# Altium schematic sheet ExternalI2C.SchDoc(ExternalI2C)
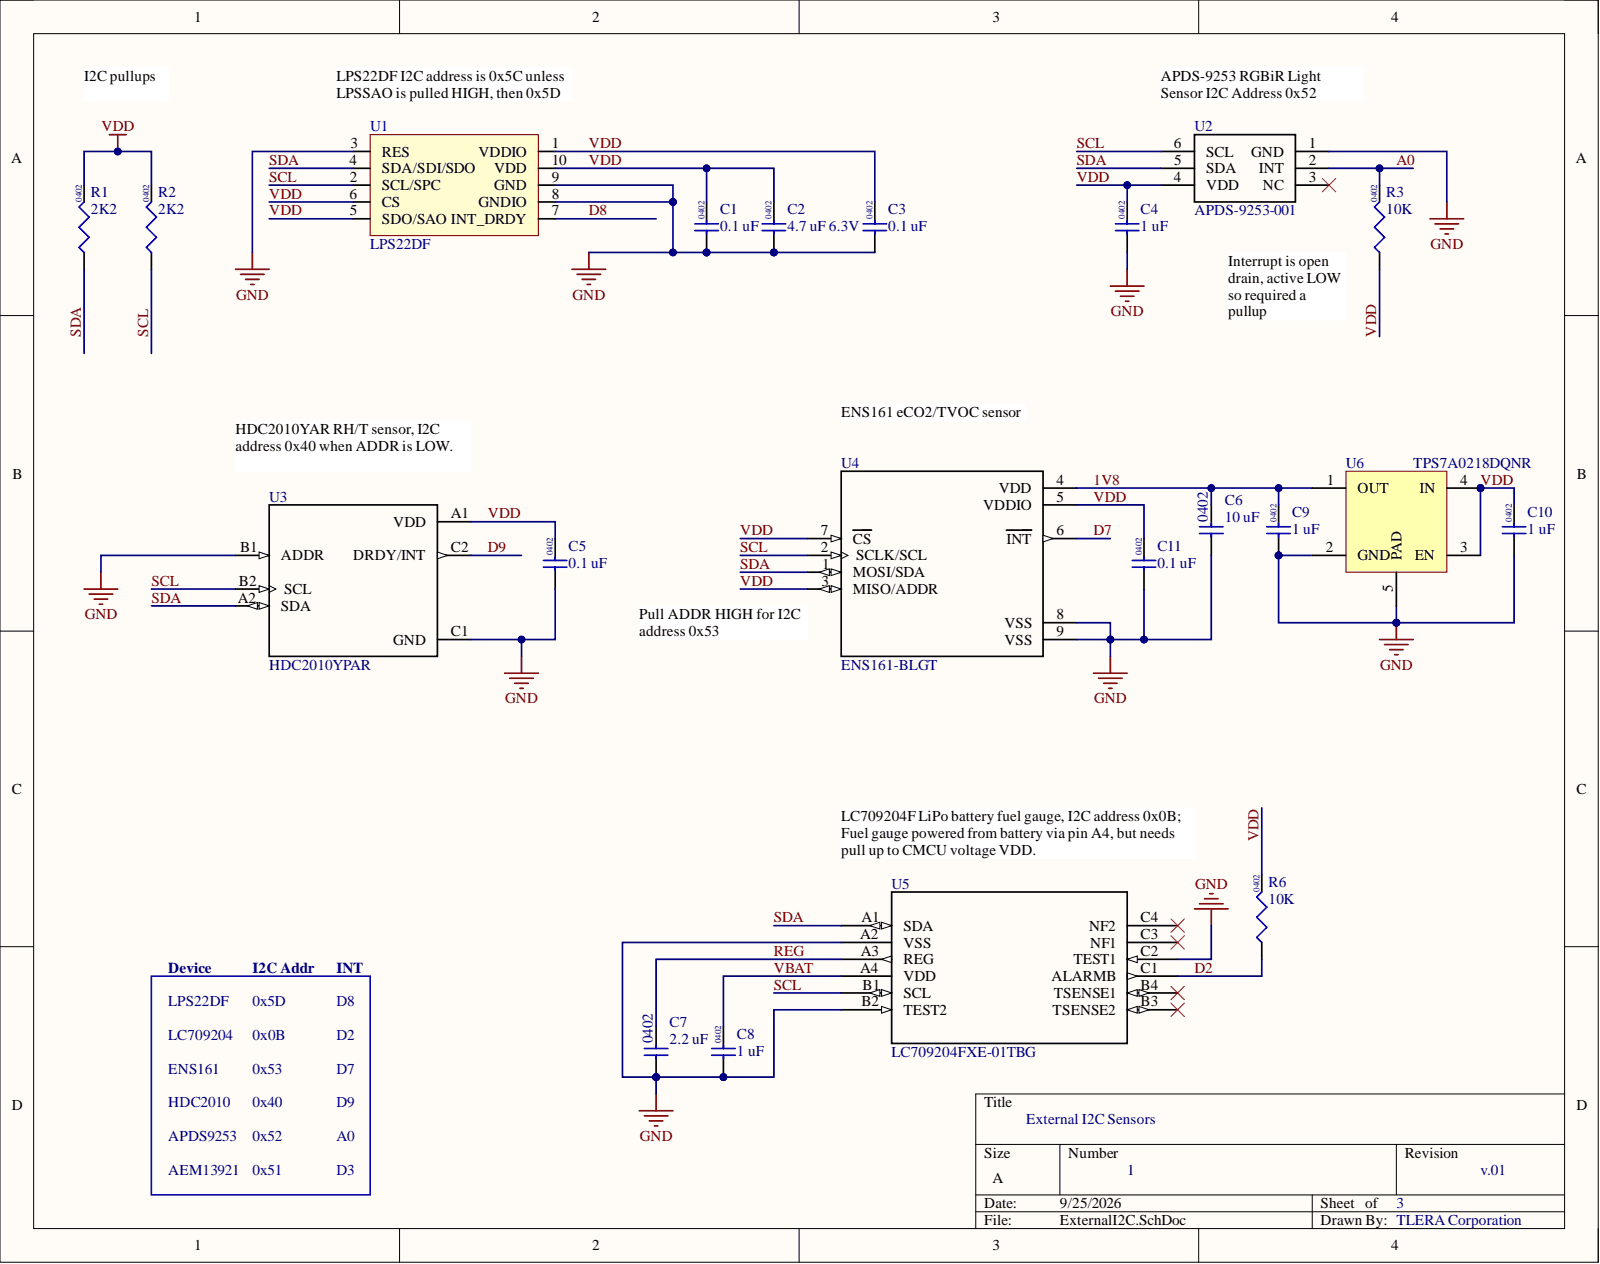
[diagram p0: ExternalI2C.SchDoc]

## Parts
C1  0.1 uF  Size Code: 0402
C2  4.7 uF 6.3V  Size Code: 0402
C3  0.1 uF  Size Code: 0402
C4  1 uF  Size Code: 0402
C5  0.1 uF  Size Code: 0402
C6  10 uF  Size Code: 0402
C7  2.2 uF  Size Code: 0402
C8  1 uF  Size Code: 0402
C9  1 uF  Size Code: 0402
C10  1 uF  Size Code: 0402
C11  0.1 uF  Size Code: 0402
R1  2K2
R2  2K2
R3  10K  Size Code: 0402
R6  10K  Size Code: 0402
U1  LPS22DF
U2  APDS-9253-001
U3  HDC2010YPAR
U4  ENS161-BLGT
U5  LC709204FXE-01TBG
U6  TPS7A0218DQNR

## Nets
GND: C1.1 C2.1 C3.1 C4.1 C5.1 C6.1 C7.1 C8.1 C9.1 C10.1 C11.1 U1.3(RES) U1.8(GNDIO) U1.9(GND) U2.1(GND) U3.B1(ADDR) U3.C1(GND) U4.8(VSS) U4.9(VSS) U5.A2(VSS) U5.B2(TEST2) U5.C2(TEST1) U6.2(GND) U6.5(PAD)
1V8: C6.2 C9.2 U4.4(VDD) U6.1(OUT)
A0: R3.2 U2.2(INT)
D2: R6.1 U5.C1(ALARMB)
D7: U4.6(INT)
D8: U1.7(INT_DRDY)
D9: U3.C2(DRDY/INT)
REG: C7.2 U5.A3(REG)
SCL: R2.1 U1.2(SCL/SPC) U2.6(SCL) U3.B2(SCL) U4.2(SCLK/SCL) U5.B1(SCL)
SDA: R1.1 U1.4(SDA/SDI/SDO) U2.5(SDA) U3.A2(SDA) U4.1(MOSI/SDA) U5.A1(SDA)
VBAT: C8.2 U5.A4(VDD)
VDD: C1.2 C2.2 C3.2 C4.2 C5.2 C10.2 C11.2 R1.2 R2.2 R3.1 R6.2 U1.1(VDDIO) U1.5(SDO/SAO) U1.6(CS) U1.10(VDD) U2.4(VDD) U3.A1(VDD) U4.3(MISO/ADDR) U4.5(VDDIO) U4.7(CS) U6.3(EN) U6.4(IN)

Not connected: U2 3(NC); U5 B3(TSENSE2) B4(TSENSE1) C3(NF1) C4(NF2)

## Library data of the parts
C1 C3 C5 C11 = CL05B104KO5NNNC: 0402 0.1 uF Ceramic Capacitor, Multilayer, Ceramic, 16V, 10% +Tol, 10% -Tol, X7R, 15% TC, 0.1uF, Surface Mount, 0402; Manufacturer: Samsung; Capacitance: 100nF; Multilayer: Yes; Capacitor Type: Ceramic; Category: Ceramic Capacitors; Component Kind: Standard; ComponentLink1Description: https://datasheet.ciiva.com:443/pdfs/VipMasterIC/IC/SEMS/SEMS-S-A0008384515/SEMS-S-A0008872921-1.pdf?src-supplier=IHS+Markit; ComponentLink1URL: https://datasheet.ciiva.com:443/pdfs/VipMasterIC/IC/SEMS/SEMS-S-A0008384515/SEMS-S-A0008872921-1.pdf?src-supplier=IHS+Markit; ComponentLink2Description: https://datasheet.ciiva.com/26920/getdatasheetpartid-359683-26920169.pdf?src-supplier=Verical; ComponentLink2URL: https://datasheet.ciiva.com/26920/getdatasheetpartid-359683-26920169.pdf?src-supplier=Verical; ComponentLink3Description: https://datasheet.ciiva.com/29198/458718-da-01-en-vs-kondensator-0402-29198423.pdf?src-supplier=Conrad; ComponentLink3URL: https://datasheet.ciiva.com/29198/458718-da-01-en-vs-kondensator-0402-29198423.pdf?src-supplier=Conrad; ComponentLink4Description: https://datasheet.ciiva.com/16208/cl05b104kq5nnnc-16208343.pdf?src-supplier=Future+Electronics; ComponentLink4URL: https://datasheet.ciiva.com/16208/cl05b104kq5nnnc-16208343.pdf?src-supplier=Future+Electronics; ComponentLink5Description: https://datasheet.ciiva.com/26309/mlcc-catalogdownload2013-eng-26309003.pdf?src-supplier=Distrelec; ComponentLink5URL: https://datasheet.ciiva.com/26309/mlcc-catalogdownload2013-eng-26309003.pdf?src-supplier=Distrelec; Dielectric Material: Ceramic; Height: 0.55mm; JESD-609 Code: e3; Length: 1mm; Library Name: Sensors.SchLib; Manufacturer Part Number: CL05B104KO5NNNC; Max Operating Temperature: 125°C; Min Operating Temperature: -55°C; Mount: Surface Mount; Negative Tolerance: 10%; Number of Pins: 2; Package Shape: Rectangular; Package Style: SMTMeter; Packaging: Tape and Reel; Positive Tolerance: 10%; Pricing 1: 1=0.10000, 10=0.02600, 50=0.01400, 100=0.01150, 500=0.00820, 1000=0.00644, 2500=0.00586, 5000=0.00539 (USD); Rated DC Voltage (URdc): 16V; REACH SVHC: Yes; RoHS: Yes; RoHS Compliant: Yes; Stock 1: 30141598; Supplier 1: Digi-Key; Supplier Part Number 1: 1276-1001-1-ND; Temperature Characteristics Code: X7R; Terminal Finish: Nickel; Termination: Wraparound; Width: 0.5mm
C2 = CC0402KRX5R5BB475: 0402 4.7 uF Ceramic Capacitor, Multilayer, Ceramic, 6.3V, 10% +Tol, 10% -Tol, X5R, 15% TC, 4.7uF, Surface Mount, 0402; Manufacturer: Yageo; Multilayer: Yes; Capacitance: 4.7uF; Capacitor Type: Ceramic; Category: Ceramic Capacitors; Component Kind: Standard; ComponentLink1Description: https://datasheet.ciiva.com:443/pdfs/VipMasterIC/IC/YAGO/YAGO-S-A0006851646/YAGO-S-A0006851646-1.pdf?src-supplier=IHS+Markit; ComponentLink1URL: https://datasheet.ciiva.com:443/pdfs/VipMasterIC/IC/YAGO/YAGO-S-A0006851646/YAGO-S-A0006851646-1.pdf?src-supplier=IHS+Markit; ComponentLink2Description: http://www.yageo.com/documents/recent/UPY-GPHC_X5R_4V-to-50V_25.pdf?src-supplier=Digi-Key; ComponentLink2URL: http://www.yageo.com/documents/recent/UPY-GPHC_X5R_4V-to-50V_25.pdf?src-supplier=Digi-Key; ComponentLink3Description: https://www.yageo.com/upload/media/product/productsearch/datasheet/mlcc/UPY-GPHC_X5R_4V-to-50V_26.pdf?src-supplier=Digi-Key; ComponentLink3URL: https://www.yageo.com/upload/media/product/productsearch/datasheet/mlcc/UPY-GPHC_X5R_4V-to-50V_26.pdf?src-supplier=Digi-Key; Dielectric Material: Ceramic; Height: 0.5mm; JESD-609 Code: e3; Length: 1mm; Library Name: Sensors.SchLib; Manufacturer Part Number: CC0402KRX5R5BB475; Max Operating Temperature: 85°C; Min Operating Temperature: -55°C; Mount: Surface Mount; Negative Tolerance: 10%; Number of Pins: 2; Package Shape: Rectangular; Package Style: SMTMeter; Packaging: Tape and Reel; Positive Tolerance: 10%; Pricing 1: 1=0.19000, 10=0.12700, 50=0.07600, 100=0.06420, 500=0.04396, 1000=0.03889, 2500=0.03720, 5000=0.03381 (USD); Rated DC Voltage (URdc): 6.3V; Reach SVHC Compliant: Yes; RoHS: Yes; RoHS Compliant: Yes; Stock 1: 1142139; Supplier 1: Digi-Key; Supplier Part Number 1: 311-3476-1-ND; Temperature Characteristics Code: X5R; Terminal Finish: Nickel; Termination: Wraparound; Width: 0.5mm
C4 C8-C10 = GRT155R61C105KE01D: 0402 1 uF Ceramic Capacitor, Multilayer, Ceramic, 16V, 10% +Tol, 10% -Tol, X5R, 15% TC, 1uF, Surface Mount, 0402; Manufacturer: Murata; Multilayer: Yes; Reference Standard: AEC-Q200; Capacitance: 1uF; Capacitor Type: Ceramic; Category: Ceramic Capacitors; Component Kind: Standard; ComponentLink1Description: https://datasheet.ciiva.com:443/pdfs/VipMasterIC/IC/MURE/MURE-S-A0004410517/MURE-S-A0004410643-1.pdf?src-supplier=IHS+Markit; ComponentLink1URL: https://datasheet.ciiva.com:443/pdfs/VipMasterIC/IC/MURE/MURE-S-A0004410517/MURE-S-A0004410643-1.pdf?src-supplier=IHS+Markit; ComponentLink2Description: http://search.murata.co.jp/Ceramy/image/img/A01X/G101/ENG/GRT155R61C105KE01-01.pdf?src-supplier=Digi-Key; ComponentLink2URL: http://search.murata.co.jp/Ceramy/image/img/A01X/G101/ENG/GRT155R61C105KE01-01.pdf?src-supplier=Digi-Key; Dielectric Material: Ceramic; JESD-609 Code: e3; Library Name: Sensors.SchLib; Manufacturer Part Number: GRT155R61C105KE01D; Max Operating Temperature: 85°C; Min Operating Temperature: -55°C; Mount: Surface Mount; Negative Tolerance: 10%; Number of Pins: 2; Package Shape: Rectangular; Packaging: Tape and Reel; Positive Tolerance: 10%; Pricing 1: 1=0.12000, 10=0.08300, 50=0.04740, 100=0.03920, 500=0.02752, 1000=0.02278, 2500=0.02120, 5000=0.01939 (USD); Rated DC Voltage (URdc): 16V; REACH SVHC: Yes; RoHS: Yes; RoHS Compliant: Yes; Stock 1: 691618; Supplier 1: Digi-Key; Supplier Part Number 1: 490-12255-1-ND; Temperature Characteristics Code: X5R; Terminal Finish: Nickel; Termination: Wraparound
C6 = CL05A106MQ5NUNC: 0402 10uF Ceramic Capacitor, Multilayer, Ceramic, 6.3V, 20% +Tol, 20% -Tol, X5R, 15% TC, 10uF, Surface Mount, 0402; Manufacturer: Samsung; Multilayer: Yes; Capacitance: 10uF; Capacitor Type: Ceramic; Category: Ceramic Capacitors; Component Kind: Standard; ComponentLink1Description: https://datasheet.ciiva.com:443/pdfs/VipMasterIC/IC/SEMS/SEMS-S-A0008521219/SEMS-S-A0008521300-1.pdf?src-supplier=IHS+Markit; ComponentLink1URL: https://datasheet.ciiva.com:443/pdfs/VipMasterIC/IC/SEMS/SEMS-S-A0008521219/SEMS-S-A0008521300-1.pdf?src-supplier=IHS+Markit; ComponentLink2Description: https://datasheet.ciiva.com/16437/cl05a106mq5nunc-16437356.pdf?src-supplier=Future+Electronics; ComponentLink2URL: https://datasheet.ciiva.com/16437/cl05a106mq5nunc-16437356.pdf?src-supplier=Future+Electronics; ComponentLink3Description: https://datasheet.ciiva.com/26877/getdatasheetpartid-355289-26877841.pdf?src-supplier=Verical; ComponentLink3URL: https://datasheet.ciiva.com/26877/getdatasheetpartid-355289-26877841.pdf?src-supplier=Verical; Dielectric Material: Ceramic; Height: 0.7mm; JESD-609 Code: e3; Length: 1mm; Library Name: Sensors.SchLib; Manufacturer Part Number: CL05A106MQ5NUNC; Max Operating Temperature: 85°C; Min Operating Temperature: -55°C; Mount: Surface Mount; Negative Tolerance: 20%; Number of Pins: 2; Package Shape: Rectangular; Package Style: SMTMeter; Packaging: Tape and Reel; Positive Tolerance: 20%; Pricing 1: 1=0.26000, 10=0.17600, 50=0.10540, 100=0.08900, 500=0.06086, 1000=0.05384, 2500=0.05150, 5000=0.04682 (USD); Rated DC Voltage (URdc): 6.3V; REACH SVHC: Yes; RoHS: Yes; RoHS Compliant: Yes; Stock 1: 9272723; Supplier 1: Digi-Key; Supplier Part Number 1: 1276-1451-1-ND; Temperature Characteristics Code: X5R; Terminal Finish: Nickel; Termination: Wraparound; Width: 0.5mm
C7 = CL05A225KO5NQNC: 0402 2.2 uF Ceramic Capacitor, Multilayer, Ceramic, 16V, 10% +Tol, 10% -Tol, X5R, 15% TC, 2.2uF, Surface Mount, 0402; Manufacturer: Samsung; Multilayer: Yes; Capacitance: 2.2uF; Capacitor Type: Ceramic; Category: Ceramic Capacitors; Component Kind: Standard; ComponentLink1Description: https://datasheet.ciiva.com:443/pdfs/VipMasterIC/IC/SEMS/SEMS-S-A0008521219/SEMS-S-A0008521352-1.pdf?src-supplier=IHS+Markit; ComponentLink1URL: https://datasheet.ciiva.com:443/pdfs/VipMasterIC/IC/SEMS/SEMS-S-A0008521219/SEMS-S-A0008521352-1.pdf?src-supplier=IHS+Markit; ComponentLink2Description: https://datasheet.ciiva.com/25724/cl05a225ko5nqnc-25724388.pdf?src-supplier=Digi-Key; ComponentLink2URL: https://datasheet.ciiva.com/25724/cl05a225ko5nqnc-25724388.pdf?src-supplier=Digi-Key; ComponentLink3Description: https://datasheet.ciiva.com/26838/getdatasheetpartid-357511-26838176.pdf?src-supplier=Verical; ComponentLink3URL: https://datasheet.ciiva.com/26838/getdatasheetpartid-357511-26838176.pdf?src-supplier=Verical; ComponentLink4Description: https://datasheet.ciiva.com/29198/459013-da-01-en-vs-kondensator-0402-29198323.pdf?src-supplier=Conrad; ComponentLink4URL: https://datasheet.ciiva.com/29198/459013-da-01-en-vs-kondensator-0402-29198323.pdf?src-supplier=Conrad; Dielectric Material: Ceramic; Height: 0.6mm; JESD-609 Code: e3; Length: 1mm; Library Name: Sensors.SchLib; Manufacturer Part Number: CL05A225KO5NQNC; Max Operating Temperature: 85°C; Min Operating Temperature: -55°C; Mount: Surface Mount; Negative Tolerance: 10%; Number of Pins: 2; Package Shape: Rectangular; Package Style: SMTMeter; Packaging: Tape and Reel; Positive Tolerance: 10%; Pricing 1: 1=0.29000, 10=0.19700, 50=0.11820, 100=0.09980, 500=0.06830, 1000=0.06042, 2500=0.05779, 5000=0.05254 (USD); Rated DC Voltage (URdc): 16V; REACH SVHC: Yes; RoHS: Yes; RoHS Compliant: Yes; Stock 1: 607636; Supplier 1: Digi-Key; Supplier Part Number 1: 1276-1461-1-ND; Temperature Characteristics Code: X5R; Terminal Finish: Nickel; Termination: Wraparound; Width: 0.5mm
R1 R2 = RMCF0402FT2K20: 0402 2.2 kOhms ±1% 0.063W, 1/16W Chip Resistor 0402 (1005 Metric) Automotive AEC-Q200 Thick Film; Component Kind: Standard; Library Name: Sensors.SchLib; Supplier 1: Avnet; Supplier Part Number 1: RMCF0402FT2K20
R3 R6 = CR0402-FX-1002GLF: 0402 10K Fixed Resistor, Metal Glaze/thick Film, 0.0625W, 10000ohm, 50V, 1% +/-Tol, 100ppm/Cel, Surface Mount, 0402; Manufacturer: Bourns; Package Height: 0.35mm; Package Length: 1mm; Package Width: 0.5mm; Rated Power Dissipation (P): 62.5mW; Rated Temperature: 70°C; Resistance: 10kR; Temperature Coefficient: 100ppm/°C; Category: Chip SMD Resistors; Component Kind: Standard; ComponentLink1Description: https://datasheet.ciiva.com:443/pdfs/VipMasterIC/IC/BOUR/BOUR-S-A0008953887/BOUR-S-A0008953887-1.pdf?src-supplier=IHS+Markit; ComponentLink1URL: https://datasheet.ciiva.com:443/pdfs/VipMasterIC/IC/BOUR/BOUR-S-A0008953887/BOUR-S-A0008953887-1.pdf?src-supplier=IHS+Markit; ComponentLink2Description: https://datasheet.ciiva.com/30490/0900766b812730ae-30490501.pdf?src-supplier=RSComponents; ComponentLink2URL: https://datasheet.ciiva.com/30490/0900766b812730ae-30490501.pdf?src-supplier=RSComponents; ComponentLink3Description: https://datasheet.ciiva.com/3255/cr0402-3255916.pdf?src-supplier=Avnet; ComponentLink3URL: https://datasheet.ciiva.com/3255/cr0402-3255916.pdf?src-supplier=Avnet; ComponentLink4Description: https://datasheet.ciiva.com/6562/cr0402-6562871.pdf?src-supplier=Digi-Key; ComponentLink4URL: https://datasheet.ciiva.com/6562/cr0402-6562871.pdf?src-supplier=Digi-Key; ComponentLink5Description: https://datasheet.ciiva.com/6562/cr0402-6562873.pdf?src-supplier=Digi-Key; ComponentLink5URL: https://datasheet.ciiva.com/6562/cr0402-6562873.pdf?src-supplier=Digi-Key; ComponentLink6Description: https://datasheet.ciiva.com/6562/cr0402-6562911.pdf?src-supplier=Digi-Key; ComponentLink6URL: https://datasheet.ciiva.com/6562/cr0402-6562911.pdf?src-supplier=Digi-Key; ComponentLink7Description: https://datasheet.ciiva.com/7606/1896412-7606203.pdf?src-supplier=Newark; ComponentLink7URL: https://datasheet.ciiva.com/7606/1896412-7606203.pdf?src-supplier=Newark; ComponentLink8Description: https://datasheet.ciiva.com/22644/659744-22644166.pdf?src-supplier=Element14; ComponentLink8URL: https://datasheet.ciiva.com/22644/659744-22644166.pdf?src-supplier=Element14; ComponentLink9Description: https://datasheet.ciiva.com/22713/659744-22713205.pdf?src-supplier=Element14; ComponentLink9URL: https://datasheet.ciiva.com/22713/659744-22713205.pdf?src-supplier=Element14; ComponentLink10Description: https://datasheet.ciiva.com/30133/cr0402-30133006.pdf?src-supplier=Onlinecomponents.com; ComponentLink10URL: https://datasheet.ciiva.com/30133/cr0402-30133006.pdf?src-supplier=Onlinecomponents.com; Construction: Chip; JESD-609 Code: e3; Library Name: Sensors.SchLib; Manufacturer Part Number: CR0402-FX-1002GLF; Max Operating Temperature: 125°C; Min Operating Temperature: -55°C; Mount: Surface Mount; Number of Pins: 2; Package Shape: Rectangular; Package Style: SMTMeter; Packaging: Tape and Reel; Pricing 1: 1=0.10000, 10=0.01000, 100=0.00350, 1000=0.00158, 2500=0.00138, 5000=0.00114 (USD); REACH SVHC: Yes; Resistor Type: FIXED RESISTOR; RoHS: Yes; RoHS Compliant: Yes; Stock 1: 1486885; Supplier 1: Digi-Key; Supplier Part Number 1: CR0402-FX-1002GLFDKR-ND; Technology: RUTHENIUM OXIDE; Terminal Finish: Nickel; Termination: Wraparound; Tolerance: 1%; Working Voltage: 50V
U1 = LPS22DF: Pressure Sensor, Absolute, 18.275 Psi Rohs Compliant: Yes; Manufacturer: STMicroelectronics; Manufacturer Part Number: LPS22DFTR; Category: Pressure Sensors; Component Kind: Standard; Library Name: Sensors.SchLib; Pricing: 1=4.12, 10=2.82, 50=2.82, 100=2.69, 500=2.32, 1000=2.2, 2500=2.17, 5000=2.13, 8000=2.07, 10000=2.07 (USD); RoHS: No; Stock: 5890; Supplier: Mouser; Supplier Part Number: 511-LPS22DFTR
U2 = APDS-9253-001: APDS-9253-001 Broadcom, Ambient Light Sensor, Ambient Light 470 (Blue) nm, 550 (Green) nm, 610 (Red) nm I2C 6-Pin ODFN; Manufacturer_Name: Avago Technologies; Geometry.Height: 0.7mm; Component Kind: Standard; Datasheet Link: https://docs.broadcom.com/docs/APDS-9253-001-DS; Library Name: Sensors.SchLib; Manufacturer_Part_Number: APDS-9253-001; Mouser Part Number: 630-APDS-9253-001; Mouser Price/Stock: https://www.mouser.co.uk/ProductDetail/Broadcom-Avago/APDS-9253-001?qs=T3oQrply3y9os9rwnJ1p%252Bg%3D%3D; Supplier 1: Newark; Supplier Part Number 1: APDS-9253-001
U3 = HDC2010YPAR: Humidity, Temperature 0 ~ 100% RH I2C ±2% 8 s Surface Mount; MF: {'manufacturer': u'Texas Instruments'}; Availability: Unavailable; Component Kind: Standard; Library Name: Sensors.SchLib; MP: HDC2010YPAR; Package: None; Price: None; Supplier 1: Arrow Electronics; Supplier Part Number 1: HDC2010YPAR
U4 = ENS161-BLGT: AIR QUALITY SENSOR, MULTI GAS, L; MF: ScioSense; Check_prices: https://www.snapeda.com/parts/ENS161-BLGT/ScioSense/view-part/?ref=eda; Component Kind: Standard; Library Name: Sensors.SchLib; MP: ENS161-BLGT; Package: NON STANDARD-5 ScioSense; SnapEDA_Link: https://www.snapeda.com/parts/ENS161-BLGT/ScioSense/view-part/?ref=snap; Supplier 1: DigiKey; Supplier Part Number 1: 2618-ENS161-BLGTCT-ND
U5 = LC709204FXE-01TBG: Battery Battery Monitor IC Lithium Ion/Polymer 12-WLCSP (1.48x1.91); Manufacturer_Name: onsemi; Component Kind: Standard; Library Name: Sensors.SchLib; Manufacturer_Part_Number: LC709204FXE-01TBG; RefDes: RefDes; Supplier 1: Newark; Supplier Part Number 1: LC709204FXE-01TBG; Type: Power Management; Copyright: Copyright (C) 2025 Ultra Librarian. All rights reserved.
U6 = TPS7A0218DQNR: 1V8 Fixed Positive LDO Regulator, 1.8V, 0.45V Dropout, PDSO4; Manufacturer: Texas Instruments; Manufacturer Part Number: TPS7A0218PDQNR; Category: Voltage Regulators - Linear; Component Kind: Standard; Library Name: Sensors.SchLib; Pricing 1: 1=1.58000, 10=1.42000, 50=1.35000, 100=1.11000, 1000=0.72100, 10000=0.69600 (USD); RoHS: Yes; Supplier 1: Mouser; Supplier Part Number 1: 595-TPS7A0218PDQNR
